# Altium schematic sheet cp2102.SchDoc(cp2102)
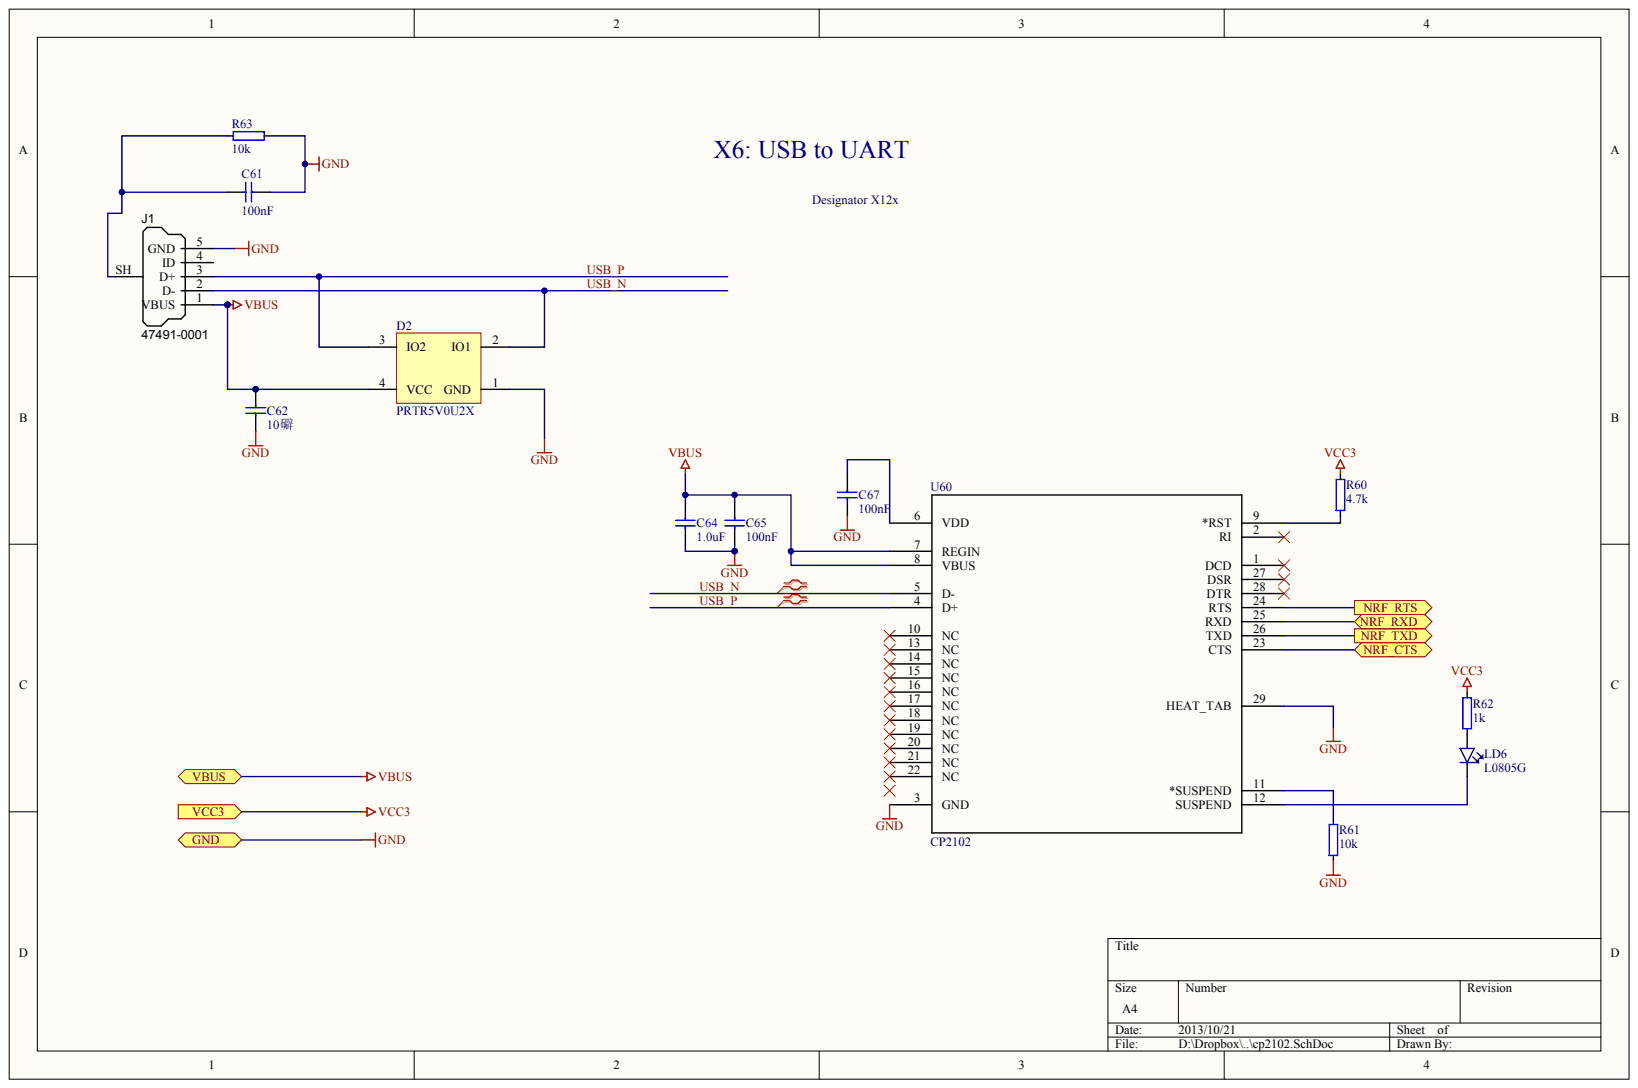
[diagram p0: cp2102.SchDoc]

## Parts
C61  100nF  [0402]
C62  10礔  [0603]
C64  1.0uF  [0603]
C65  100nF  [0402]
C67  100nF  [0402]
D2  PRTR5V0U2X  [SOT-143B]
J1  Manufacturer Part Number 1: 47491-0001  (hidden comment: USB Micro Type B)
LD6  L0805G  [0805]
R60  4.7k  [0402]
R61  10k  [0402]
R62  1k  [0402]
R63  10k  [0402]
U60  CP2102

## Ports
GND, NRF_CTS, NRF_RTS, NRF_RXD, NRF_TXD, VBUS, VCC3

## Nets
GND: C61.1 C62.1 C64.1 C65.2 C67.2 D2.1(GND) J1.5(GND) R61.2 R63.1 U60.3(GND) U60.29(HEAT_TAB) ; port GND
USB_N: D2.2(IO1) J1.2(D-) U60.5(D-)
USB_P: D2.3(IO2) J1.3(D+) U60.4(D+)
VBUS: C62.2 C64.2 C65.1 D2.4(VCC) J1.1(VBUS) U60.7(REGIN) U60.8(VBUS) ; port VBUS
VCC3: R60.1 R62.2 ; port VCC3
NetC61_2: C61.2 J1.SH R63.2
NetC67_1: C67.1 U60.6(VDD)
NetLD6_1: LD6.1 U60.12(SUSPEND)
NetLD6_2: LD6.2 R62.1
NetR60_2: R60.2 U60.9(*RST)
NetR61_1: R61.1 U60.11(*SUSPEND)
NetU60_23: U60.23(CTS) ; port NRF_CTS
NetU60_24: U60.24(RTS) ; port NRF_RTS
NetU60_25: U60.25(RXD) ; port NRF_RXD
NetU60_26: U60.26(TXD) ; port NRF_TXD

Not connected: J1 4(ID); U60 1(DCD) 2(RI) 10(NC) 13(NC) 14(NC) 15(NC) 16(NC) 17(NC) 18(NC) 19(NC) 20(NC) 21(NC) 22(NC) 27(DSR) 28(DTR)

## Library data of the parts
C61 C65 C67 = (no symbol name): Part Number: C0402-100n; Note: 16V; Artikkelnr Noca: 20039200; Manufacturer: *; Manufacturer Part Number: *; Datasheet: *; LatestRevisionDate: 2009-11-23; Pin Count: 2; Published: 2009-05-08; Value: 100nF; Supplier: *; Supplier part number: *; 2nd source Supplier: *; 2nd source part number: *; Publisher: Nordic Semiconductor
C62 = (no symbol name): Part Number: C0603-10祙; Note: 6.3V; Artikkelnr Noca: 20039252; Manufacturer: *; Manufacturer Part Number: *; Datasheet: *; LatestRevisionDate: 2011-01-17; Pin Count: 2; Published: 2011-01-17; Value: 10礔; Supplier: *; Supplier part number: *; 2nd source Supplier: *; 2nd source part number: *; Publisher: Nordic Semiconductor
C64 = (no symbol name): Part Number: C0603-1?; Note: 10V; Artikkelnr Noca: 20039205; Published: 2009-05-08; LatestRevisionDate: 2009-11-23; Manufacturer: *; Manufacturer Part Number: *; Datasheet: *; Pin Count: 2; Supplier: *; Supplier part number: *; 2nd source Supplier: *; 2nd source part number: *; Publisher: Nordic Semiconductor
D2 = (no symbol name): Manufacturer: NXP; 2nd source part number: 152-4157; Artikkelnr Noca: 10069015; Part Number: PRTR5V0U2X; Manufacturer Part Number: PRTR5V0U2X; Datasheet: http://www.farnell.com/datasheets/109718.pdf; LatestRevisionDate: 2012-06-11; Published: 2012-06-11; Value: PRTR5V0U2X; Note: *; Supplier: Digi-Key; Supplier part number: 568-4140-1-ND; 2nd source Supplier: Farnell; Publisher: Nordic Semiconductor
J1 = (no symbol name): Manufacturer 1: Molex Inc; Package / Case: SMD; Supplier 1: Digi-Key; Supplier Part Number 1: WM17142CT-ND
LD6 = (no symbol name): Part Number: SML-210PT; Manufacturer: ROHM; Manufacturer Part Number: SML-210PTT86; Note: 4 mcd @ 20 mA; Artikkelnr Noca: 13019123; Datasheet: http://no.farnell.com/rohm/sml-210dtt86/led-smd-0805-orange/dp/1685051; LatestRevisionDate: 2009-09-03; Pin Count: 2; Published: 2009-09-03; Value: L0805G; Supplier: *; Supplier part number: *; 2nd source Supplier: *; 2nd source part number: *; Publisher: Nordic Semiconductor
R60 R61 = (no symbol name): Part Number: R0402-0R; Note: Chip Res 5%; Artikkelnr Noca: 27049000; Manufacturer: *; Manufacturer Part Number: *; Datasheet: *; LatestRevisionDate: 2009-11-23; Pin Count: 2; Published: 2009-05-08; Supplier: Farnell; Supplier part number: 146-9661; 2nd source Supplier: *; 2nd source part number: *; Publisher: Nordic Semiconductor
  R60: Value: 4.7k
  R61: Value: 10k
R62 = (no symbol name): Part Number: R0402-12k; Note: Chip Res 1%; Artikkelnr Noca: 27049099; Manufacturer: *; Manufacturer Part Number: *; Datasheet: *; LatestRevisionDate: 2009-11-23; Pin Count: 2; Published: 2009-05-08; Value: 1k; Supplier: Farnell; Supplier part number: 173-8865; 2nd source Supplier: *; 2nd source part number: *; Publisher: Nordic Semiconductor
R63 = (no symbol name): Part Number: R0402-10k; Note: Chip Res 1%; Artikkelnr Noca: 27049097; Value: 10k; Manufacturer: *; Manufacturer Part Number: *; Datasheet: *; LatestRevisionDate: 2009-11-23; Pin Count: 2; Published: 2009-05-08; Supplier: Farnell; Supplier part number: 173-8864; 2nd source Supplier: *; 2nd source part number: *; Publisher: Nordic Semiconductor
U60 = (no symbol name): PART DESC: SINGLE-CHIP USB TO UART BRIDGE, QFN28, RoHS.; MFG #1: SILICON LABS; PART NUMBER: CP2102; Value: Value; RefDes: RefDes; Type: DEV
